# Altium schematic sheet MCU+PWR+USB+SPORT.SchDoc
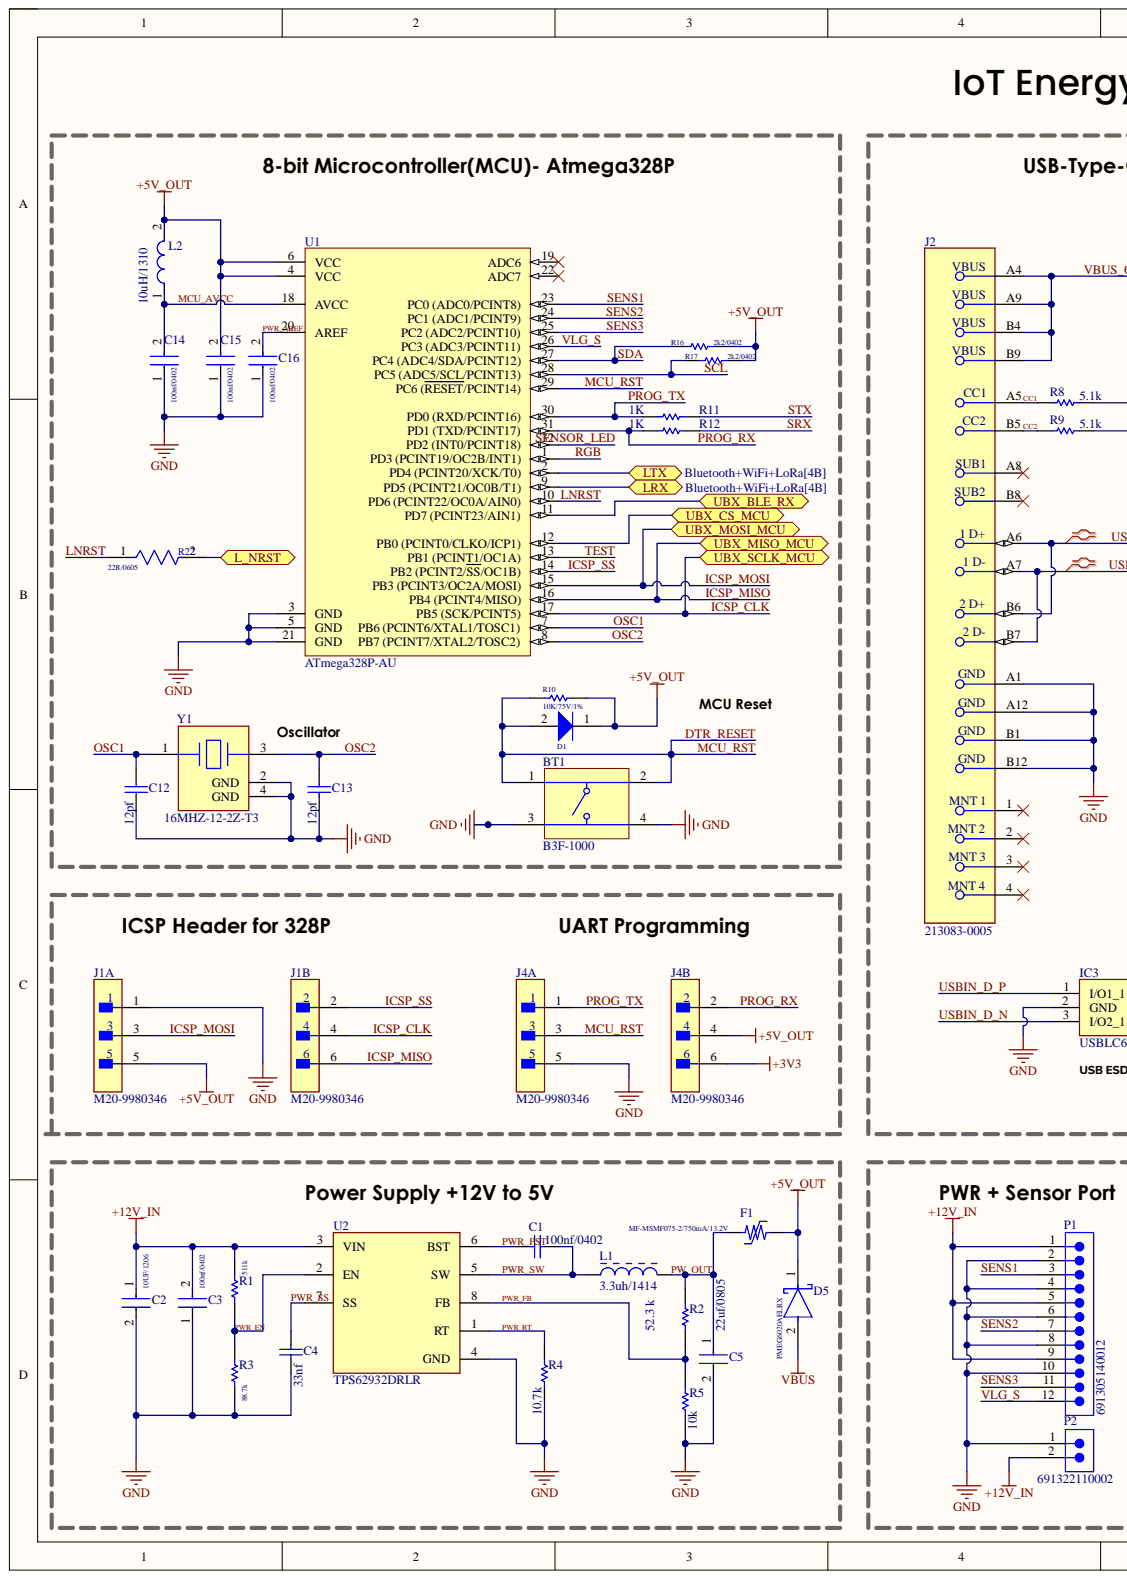
[diagram p0: MCU+PWR+USB+SPORT.SchDoc, left]
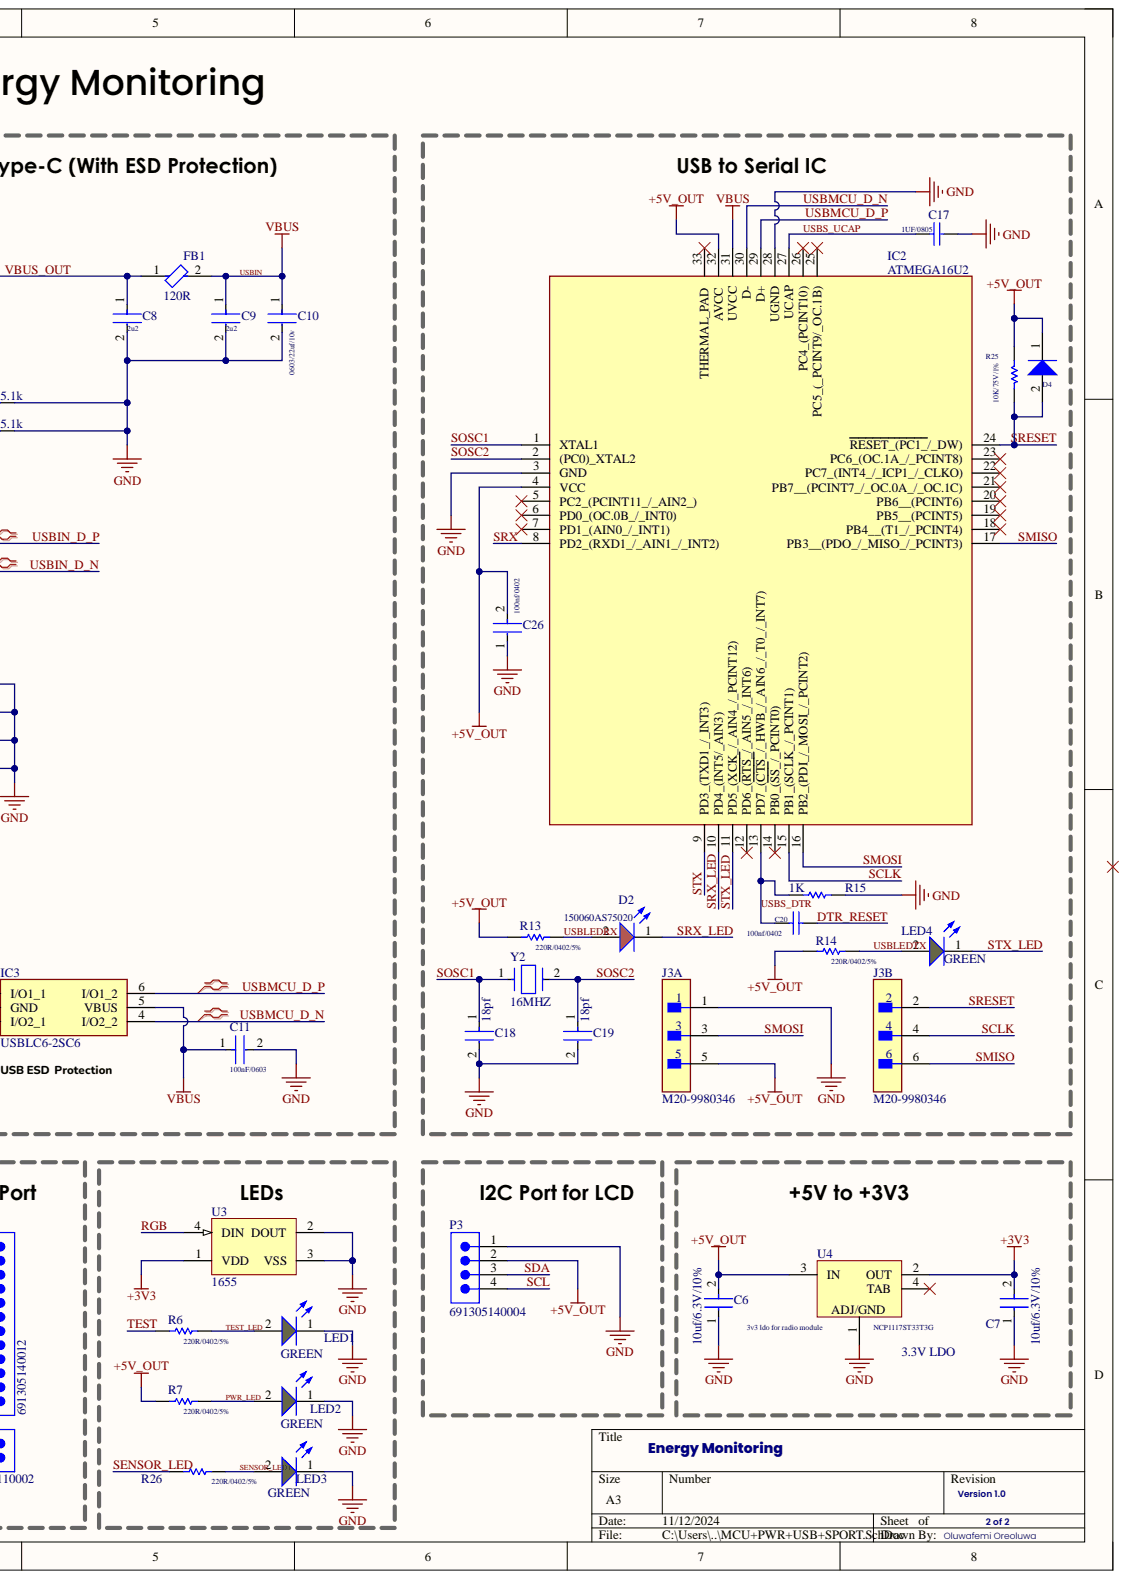
[diagram p1: MCU+PWR+USB+SPORT.SchDoc, right]

## Parts
BT1  B3F-1000  [OMRON-B3F-1000-4_V]
C1  100nf/0402  [CAPC1005X55X25LL05T10]
C2  10UF/1206  [FP-C3216-160-0_2-IPC_A]
C3  100nf/0402  [FP-GRM15-MFG]
C4  33nf  [CAPC1005X55X25NL05T10]
C5  22uf/0805  [FP-GRM21B-0_2-e0_2_0_7-IPC_C]
C6  10uf/6.3V/10%  [FP-C1608-080-0_1-IPC_B]
C7  10uf/6.3V/10%  [FP-C1608-080-0_1-IPC_B]
C8  2u2  [FP-GRM188-0_1-e0_2_0_5-MFG]
C9  2u2  [FP-GRM188-0_1-e0_2_0_5-MFG]
C10  0603/22uf/10v  [FP-GRM188-0_2-IPC_C]
C11  100nF/0603  [FP-CGA3E-0_3-0_1-IPC_A]
C12  12pf  [CAPC2013X94X50LL20T25]
C13  12pf  [CAPC2013X94X50LL20T25]
C14  100nf/0402  [FP-GRM15-MFG]
C15  100nf/0402  [FP-GRM15-MFG]
C16  100nf/0402  [FP-GRM15-MFG]
C17  1UF/0805  [CAPC2013X140X50LL20T25]
C18  18pf  [FP-C0805C-DN-MFG]
C19  18pf  [FP-C0805C-DN-MFG]
C20  100nf/0402  [CAPC1005X55X25LL05T10]
C26  100nf/0402  [FP-GRM15-MFG]
D1  BAT54HT1G/30V/2A  [ONSC-SOD-323-2-477-02_V]  (value not drawn)
D2  150060AS75020  [WL-SMCW_0603_150060xx75020]
D4  BAT54HT1G/30V/2A  [ONSC-SOD-323-2-477-02_V]  (value not drawn)
D5  PMEG6020AELRX  [FP-SOD123W-MFG]
F1  MF-MSMF075-2/750mA/13.2V  [BOUR-MF-MSMF050-2_V]
FB1  120R  [FP-BLM18-0_15-t0_8-IPC_A]
IC2  ATMEGA16U2  [QFN50P500X500X100-33N-D]
IC3  USBLC6-2SC6  [SOT95P280X145-6N]
J1  M20-9980346  [FP-M20-9980346-MFG]  (parts A,B)
J2  213083-0005  [FP-2130830005-MFG]
J3  M20-9980346  [FP-M20-9980346-MFG]  (parts A,B)
J4  M20-9980346  [FP-M20-9980346-MFG]  (parts A,B)
L1  3.3uh/1414  [BOUR-SRN3015-2_V]
L2  10uH/1310  [FP-CB3225-IPC_A]
LED1  GREEN  [WL-SMCW_0603_150060xx75000]
LED2  GREEN  [WL-SMCW_0603_150060xx75000]
LED3  GREEN  [WL-SMCW_0603_150060xx75000]
LED4  GREEN  [WL-SMCW_0603_150060xx75000]
P1  691305140012  [691305140012]
P2  691322110002  [691322110002]
P3  691305140004  [691305140004]
R1  511k  [RESC1005X40X25NL05T05]
R2  52.3 k  [RESC1005X40X25LL05T05]
R3  88.7k  [RESC1005X40X25NL05T05]
R4  10.7k  [RESC1005X40X25NL05T05]
R5  10k  [RESC1609X50X30NL8T20]
R6  220R/0402/5%  [RESC1005X40X25LL05T05]
R7  220R/0402/5%  [RESC1005X40X25LL05T05]
R8  5.1k  [RESC0805(2012)_N]
R9  5.1k  [RESC0805(2012)_N]
R10  10K/75V/1%  [RESC1609X50X30NL8T20]
R11  1K  [RESC3216X70X50LL05T20]
R12  1K  [RESC3216X70X50LL05T20]
R13  220R/0402/5%  [RESC1005X40X25LL05T05]
R14  220R/0402/5%  [RESC1005X40X25LL05T05]
R15  1K  [RESC3216X70X50LL05T20]
R16  2k2/0402  [RESC1005X40X25NL5T10]
R17  2k2/0402  [RESC1005X40X25NL5T10]
R22  22R/0605  [FP-CPF0603-MFG]
R25  10K/75V/1%  [RESC1609X50X30NL8T20]
R26  220R/0402/5%  [RESC1005X40X25LL05T05]
U1  ATmega328P-AU  [32A_M]
U2  TPS62932DRLR  [FP-DRL0008A-MFG]
U3  1655  [ADA-RGB-1655_V]
U4  NCP1117ST33T3G  [ONSC-SOT-223-4-318H-01_A_V]
Y1  16MHZ-12-2Z-T3  [FP-ABM8AIG-MFG]
Y2  16MHZ  [FP-ABM7-MFG]

## Ports
L_NRST, LRX, LTX, UBX_BLE_RX, UBX_CS_MCU, UBX_MISO_MCU, UBX_MOSI_MCU, UBX_SCLK_MCU

## Nets
+3V3: C7.2 J4.6 U3.1(VDD) U4.2(OUT)
+5V_OUT: C6.2 C15.2 C26.2 D1.1 D4.1 D5.1 F1.2 IC2.4(VCC) IC2.32(AVCC) J1.5 J3.5 J4.4 L2.2 P3.2 R7.1 R10.1 R13.1 R14.1 R16.1 R17.1 R25.1 U1.4(VCC) U1.6(VCC) U4.3(IN)
+12V_IN: C2.1 C3.2 P1.1 P1.5 P1.9 P2.2 R1.2 U2.3(VIN)
GND: BT1.3 BT1.4 C2.2 C3.1 C4.1 C5.2 C6.1 C7.1 C8.2 C9.2 C10.2 C11.2 C12.1 C13.1 C14.1 C15.1 C16.1 C17.2 C18.2 C19.2 C26.1 IC2.3(GND) IC2.28(UGND) IC3.2(GND) J1.1 J2.A1 J2.A12 J2.B1 J2.B12 J3.1 J4.5 LED1.1 LED2.1 LED3.1 P1.2 P1.4 P1.6 P1.8 P1.10 P2.1 P3.1 R3.1 R4.1 R5.1 R8.1 R9.1 R15.2 U1.3(GND) U1.5(GND) U1.21(GND) U2.4(GND) U3.2(DOUT) U3.3(VSS) U4.1 Y1.2(GND) Y1.4(GND)
CC1: J2.A5 R8.2
CC2: J2.B5 R9.2
DTR_RESET: BT1.1 BT1.2 C20.2 D1.2 J4.3 R10.2 U1.29(PC6_(RESET/PCINT14))
ICSP_CLK: J1.4 U1.17(PB5_(SCK/PCINT5)) ; port UBX_SCLK_MCU
ICSP_MISO: J1.6 U1.16(PB4_(PCINT4/MISO)) ; port UBX_MISO_MCU
ICSP_MOSI: J1.3 U1.15(PB3_(PCINT3/OC2A/MOSI)) ; port UBX_MOSI_MCU
ICSP_SS: J1.2 U1.14(PB2_(PCINT2/SS/OC1B))
L_NRST: R22.2 ; port L_NRST
LNRST: R22.1 U1.10(PD6_(PCINT22/OC0A/AIN0))
LRX: U1.9(PD5_(PCINT21/OC0B/T1)) ; port LRX
LTX: U1.2(PD4_(PCINT20/XCK/T0)) ; port LTX
MCU_AVCC: C14.2 L2.1 U1.18(AVCC)
OSC1: C12.2 U1.7 Y1.1
OSC2: C13.2 U1.8 Y1.3
PROG_RX: J4.2 R12.1 U1.31(PD1_(TXD/PCINT17))
PROG_TX: J4.1 R11.1 U1.30(PD0_(RXD/PCINT16))
PW_OUT: C5.1 F1.1 L1.2 R2.2
PWR_AREF: C16.2 U1.20(AREF)
PWR_EN: R1.1 R3.2 U2.2(EN)
PWR_FB: R2.1 R5.2 U2.8(FB)
PWR_LED: LED2.2 R7.2
PWR_PST: C1.1 U2.6(BST)
PWR_RT: R4.2 U2.1(RT)
PWR_SS: C4.2 U2.7(SS)
PWR_SW: C1.2 L1.1 U2.5(SW)
RGB: U1.1(PD3_(PCINT19/OC2B/INT1)) U3.4(DIN)
SCL: P3.4 R17.2 U1.28(PC5_(ADC5/SCL/PCINT13))
SCLK: IC2.15(PB1_(SCLK_/_PCINT1)) J3.4
SDA: P3.3 R16.2 U1.27(PC4_(ADC4/SDA/PCINT12))
SENS1: P1.3 U1.23(PC0_(ADC0/PCINT8))
SENS2: P1.7 U1.24(PC1_(ADC1/PCINT9))
SENS3: P1.11 U1.25
SENSOR_LED: R26.1 U1.32(PD2_(INT0/PCINT18))
SENSOR_LED1: LED3.2 R26.2
SMISO: IC2.17(PB3__(PDO_/_MISO_/_PCINT3)) J3.6
SMOSI: IC2.16(PB2_(PDI_/_MOSI_/_PCINT2)) J3.3
SOSC1: C18.1 IC2.1(XTAL1) Y2.1
SOSC2: C19.1 IC2.2((PC0)_XTAL2) Y2.2
SRESET: D4.2 IC2.24(RESET_(PC1_/_DW)) J3.2 R25.2
SRX: IC2.8(PD2_(RXD1_/_AIN1_/_INT2)) R12.2
SRX_LED: D2.1 IC2.10(PD4_(INT5/_AIN3))
STX: IC2.9(PD3_(TXD1_/_INT3)) R11.2
STX_LED: IC2.11(PD5_(XCK_/_AIN4_/_PCINT12)) LED4.1
TEST: R6.1 U1.13(PB1_(PCINT1/OC1A))
TEST_LED: LED1.2 R6.2
UBX_BLE_RX: U1.11(PD7_(PCINT23/AIN1)) ; port UBX_BLE_RX
UBX_CS_MCU: U1.12(PB0_(PCINT0/CLKO/ICP1)) ; port UBX_CS_MCU
USBIN: C9.1 C10.1 C11.1 D5.2 FB1.2 IC2.31(UVCC) IC3.5(VBUS)
USBIN_D_N: IC3.3(I/O2_1) J2.A7 J2.B7
USBIN_D_P: IC3.1(I/O1_1) J2.A6 J2.B6
USBLEDRX: D2.2 R13.2
USBLEDTX: LED4.2 R14.2
USBMCU_D_N: IC2.30(D-) IC3.4(I/O2_2)
USBMCU_D_P: IC2.29(D+) IC3.6(I/O1_2)
USBS_DTR: C20.1 IC2.13(PD7_(CTS_/_HWB_/_AIN6_/_T0_/_INT7)) R15.1
USBS_UCAP: C17.1 IC2.27(UCAP)
VBUS_OUT: C8.1 FB1.1 J2.A4 J2.A9 J2.B4 J2.B9
VLG_S: P1.12 U1.26(PC3_(ADC3/PCINT11))

Not connected: IC2 5(PC2_(PCINT11_/_AIN2_)) 6(PD0_(OC.0B_/_INT0)) 7(PD1_(AIN0_/_INT1)) 12(PD6_(RTS_/_AIN5_/_INT6)) 14(PB0_(SS_/_PCINT0)) 18(PB4__(T1_/_PCINT4)) 19(PB5__(PCINT5)) 20(PB6__(PCINT6)) 21(PB7__(PCINT7_/_OC.0A_/_OC.1C)) 22(PC7_(INT4_/_ICP1_/_CLKO)) 23(PC6_(OC.1A_/_PCINT8)) 25(PC5_(_PCINT9/_OC.1B)) 26(PC4_(PCINT10)) 33(THERMAL_PAD); J2 1 2 3 4 A8 B8; U1 19(ADC6) 22(ADC7); U4 4(TAB)

## Library data of the parts
BT1 = CMP-1677-00002-1: Tactile Switch, SPST-NO, 0.05 A, 5 to 24 V, -25 to 70 degC, 4-Pin THD, RoHS, Bulk; Capacitance: -45 f; Current Rating: 1.5 A; Gender: Female; Shielding: Shielded; Voltage Rating: 150 V; Component Kind: Standard; ComponentLink1Description: Manufacturer URL; ComponentLink1URL: http://www.omron.com/; ComponentLink2Description: Datasheet; ComponentLink2URL: http://www.omron.com/ecb/products/pdf/en-b3f.pdf; Contact Material: Brass; Contact Plating: Gold; DatasheetVersion: Jan-15; Height: 14.99 mm; Lead Pitch: 1.27 mm; Length: 18.34 mm; Library Name: Altium Content Vault; Max Operating Temperature: 70 degC; Min Operating Temperature: -40 degC; Mount: Through Hole; Package Quantity: 1080; PackageDescription: 4-Pin Through Hole Device, Body 6 x 6 mm; PackageReference: B3F-1000; Packaging: Tray; Pins: 8; Radiation Hardening: No; RoHSCompliant: true; Width: 25.4 mm
C1 C20 = CMP-2000-06331-1: Chip Capacitor, 0.1 uF, +/- 10%, 10 V, -55 to 125 degC, 0402 (1005 Metric), RoHS, Tape and Reel; Manufacturer: Murata; Capacitance: 100000pF; Dielectric: X7R; Rated Voltage: 10V; Case Size/Dimension: 0402; Component Kind: Standard; Datasheet URL: http://search.murata.co.jp/Ceramy/image/img/A01X/G101/ENG/GRM155R71A104KA01-01.pdf; Datasheet Version: 02/2016; Library Name: Altium Content Vault; Manufacturer URL: http://www.murata.com/; Mounting Technology: SurfaceMount; Package Description: 2-Pin Surface Mount Device, Body 1 x 0.5 mm; Package Reference: 0402; Tolerance (%): ±10%
C2 = CMP-2000-06045-2: Multilayer Ceramic Capacitors 10uF ±10% 50V X5R SMD 1206; Capacitance: 10uF; Case Code (Imperial): 1206; Case Code (Metric): 3216; Case/Package: 1206; Component Kind: Standard; Construction: Flat; Depth: 1.6mm; Dielectric: X5R; Dielectric Material: Ceramic; Height: 1.6mm; Lead Free: Lead Free; Length: 3.2mm; Library Name: Altium Content Vault; Max Operating Temperature: 85°C; Min Operating Temperature: -55°C; Mount: Surface Mount; Package Quantity: 1; Packaging: Tape and Reel; Radiation Hardening: No; REACH SVHC: No SVHC; Resistor Type: Ceramic; RoHS Compliant: Yes; Series: C; Termination: SMD/SMT; Thickness: 0.071inch; Tolerance: 10%; Voltage: 50V; Voltage Rating: 50V; Voltage Rating (DC): 50V; Weight: 0.000571oz; Width: 0.063inch
C3 C14-C16 C26 = CMP-2100-03641-2: CAP CER 0.1UF 16V X7R 0402; Manufacturer: Murata; Manufacturer Part Number: GRM155R71C104KA88D; Capacitance: 0.10μF; Material: Ceramic; Standoff Height: 0mm; Tolerance: 10%; Value: 0.10μF; Voltage Rating: 16Vdc; Category: Cap; Component Kind: Standard; ComponentLink2Description: Datasheet; ComponentLink2URL: https://search.murata.co.jp/Ceramy/image/img/A01X/G101/ENG/GRM155R71H471KA01-01A.pdf; Device Class L1: Passive Components; Device Class L2: Capacitors; Device Class L3: Ceramic Capacitors; Digikey Description: CAP CER 0.1UF 16V X7R 0402; Height: 0.55mm; Ipc Land Pattern Name: CAPC10050X219; Lead Free: yes; Library Name: Altium Content Vault; Mouser Description: 0402 0.1 uF 16V ±10 % Tolerance X7R SMT Multilayer Ceramic Capacitor; Octopart: https://octopart.com/grm155r71c104ka88d-murata-196880; Package: GRM15; RoHS: TRUE; Temperature: -55°C to +125°C; Temperature Characteristic: X7R; Temperature Range High: +125°C; Temperature Range Low: -55°C
C4 = CMP-2008-04594-1: Manufacturer: Murata; Part Number: GRM155R71A333KA01D; Component Kind: Standard; Library Name: Altium Content Vault
C5 = CMP-06035-039918-1: Chip Multilayer Ceramic Capacitors for General Purpose, 0805, 22uF, X5R, 15%, 20%, 10V; Dissipation Factor: 0.035%; Capacitance: 22uF; Case Code (Imperial): 0805; Case Code (Metric): 2012; Case/Package: 0805; Component Kind: Standard; Depth: 1.25mm; Dielectric: X5R; Dielectric Material: Ceramic; Height: 1.25mm; Lead Free: Lead Free; Length: 2mm; Library Name: Altium Content Vault; Max Operating Temperature: 85°C; Min Operating Temperature: -55°C; Mount: Surface Mount; Package Quantity: 3000; Packaging: Tape and Reel; Resistor Type: Ceramic; Termination: SMD/SMT; Thickness: 0.057inch; Tolerance: 20%; Voltage: 10V; Voltage Rating: 10V; Voltage Rating (DC): 10V; Weight: 0.000335oz; Width: 1.25mm
C6 C7 = CMP-08246-005333-1: Multilayer Ceramic Capacitors 10μF ±10% 6.3V X5R SMD 0603; Capacitance: 10uF; Case Code (Imperial): 0603; Case Code (Metric): 1608; Case/Package: 0603; Component Kind: Standard; Construction: Flat; Depth: 0.8mm; Dielectric: X5R; Dielectric Material: Ceramic; Height: 0.8mm; Lead Free: Lead Free; Length: 1.6mm; Library Name: Altium Content Vault; Max Operating Temperature: 85°C; Min Operating Temperature: -55°C; Mount: Surface Mount; Package Quantity: 1; Packaging: Tape and Reel; Radiation Hardening: No; REACH SVHC: No SVHC; Resistor Type: Ceramic; RoHS Compliant: Yes; Series: C; Termination: SMD/SMT; Thickness: 0.035inch; Tolerance: 10%; Voltage: 6.3V; Voltage Rating: 6.3V; Voltage Rating (DC): 6.3V; Weight: 7.1E-05oz; Width: 0.031inch
C8 C9 = CMP-2006-02836-2: Chip Multilayer Ceramic Capacitors for General Purpose, 0603, 2.2uF, X7R, 15%, 10%, 10V; Capacitance: 2.2uF; Case Code (Imperial): 0603; Case Code (Metric): 1608; Case/Package: 0603; Component Kind: Standard; Depth: 0.8mm; Dielectric: X7R; Height: 0.8mm; Lead Free: Lead Free; Length: 1.6mm; Library Name: Altium Content Vault; Material: Ceramic; Max Operating Temperature: 125°C; Min Operating Temperature: -55°C; Mount: Surface Mount; Number of Pins: 2; Package Quantity: 1; Packaging: Cut Tape; REACH SVHC: No SVHC; Termination: SMD/SMT; Thickness: 0.035inch; Tolerance: 10%; Voltage: 10V; Voltage Rating: 10V; Voltage Rating (DC): 10V; Weight: 7.1E-05oz; Width: 0.031inch
C10 = CMP-2000-06337-3: Chip Multilayer Ceramic Capacitors for General Purpose, 0603, 22uF, X5R, 15%, 20%, 10V; Capacitance: 22uF; Case Code (Imperial): 0603; Case Code (Metric): 1608; Case/Package: 0603; Component Kind: Standard; Depth: 0.8mm; Dielectric: X5R; Height: 0.8mm; Lead Free: Lead Free; Length: 1.6mm; Library Name: Altium Content Vault; Material: Ceramic; Max Operating Temperature: 85°C; Min Operating Temperature: -55°C; Mount: Surface Mount; Package Quantity: 4000; Packaging: Tape and Reel; REACH SVHC: No SVHC; Termination: SMD/SMT; Thickness: 0.039inch; Tolerance: 20%; Voltage Rating: 10V; Voltage Rating (DC): 10V; Weight: 0.000222oz; Width: 0.8mm
C11 = CMP-2000-07546-2: Cap Ceramic 100nF 50V X7R 10% Pad SMD 0603 +125°C Automotive T/R; Capacitance: 100nF; Case Code (Imperial): 0603; Case Code (Metric): 1608; Case/Package: 0603; Component Kind: Standard; Composition: Ceramic; Depth: 0.8mm; Dielectric: X7R; Height: 0.8mm; Lead Free: Lead Free; Length: 1.6mm; Library Name: Altium Content Vault; Max Operating Temperature: 125°C; Min Operating Temperature: -55°C; Mount: Surface Mount; Package Quantity: 1; Packaging: Tape and Reel; Radiation Hardening: No; Ratings: AEC-Q200; REACH SVHC: No SVHC; Termination: SMD/SMT; Thickness: 0.035inch; Tolerance: 10%; Voltage: 50V; Voltage Rating: 50V; Voltage Rating (DC): 50V; Weight: 7.1E-05oz; Width: 0.8mm
C12 C13 = CMP-2007-04128-1: Manufacturer: AVX Interconnect / Elco; Part Number: 08051A120JAT2A; Component Kind: Standard; Library Name: Altium Content Vault
C17 = CMP-2007-03234-1: Manufacturer: Kemet; Part Number: C0805C105K3RACTU; Component Kind: Standard; Library Name: Altium Content Vault
C18 C19 = CMP-2007-00302-2: CAP CER 18PF 50V C0G/NP0 0805; Manufacturer: KEMET; Manufacturer Part Number: C0805C180J5GACTU; Material: Ceramic; Temperature Characteristic: C0G; Temperature Coefficient: 30ppm/°C; Tolerance: 0.05; Automotive: No; Capacitance: 18 pF; Category: Cap; Component Kind: Standard; ComponentLink2Description: Datasheet; ComponentLink2URL: https://content.kemet.com/datasheets/KEM_C1003_C0G_SMD.pdf; Device Class L1: Passive Components; Device Class L2: Capacitors; Device Class L3: Ceramic Capacitors; Digikey Description: CAP CER 18PF 50V C0G/NP0 0805; Height: 0.88mm; Ipc Land Pattern Name: CAPC200125X78; Lead Free: Yes; Library Name: Altium Content Vault; Mouser Description: Cap Ceramic 18pF 50V C0G 5% SMD 0805 125C Paper T/R; Octopart: https://octopart.com/c0805c180j5gactu-kemet-144810; Package: C0805C-DN; RoHS: TRUE; Temperature: -55°C to +125°C; Temperature Range High: +125°C; Temperature Range Low: -55°C; Value: 18 pF; Voltage Rating: 50 V
D1 D4 = CMP-1055-00462-1: Schottky Barrier Diode, 2-Pin SOD-323, Pb-Free, Tape and Reel; Manufacturer: On Semiconductor; Configuration: Single; IFSM Max (A): 0.6; trr Max (ns): 5; Component Kind: Standard; ComponentLink1Description: Manufacturer URL; ComponentLink1URL: http://www.onsemi.com/; ComponentLink2Description: Datasheet; ComponentLink2URL: http://www.onsemi.cn/pub_link/Collateral/BAT54HT1-D.PDF; ComponentLink3Description: Package Specification; ComponentLink3URL: http://www.onsemi.com/pub_link/Collateral/477-02.PDF.1; DatasheetVersion: Rev. 6; Library Name: Altium Content Vault; Mounting Technology: Surface Mount; PackageDescription: 2-Pin SOD, Body 1.7 x 1.25 mm; PackageReference: SOD-323-2-477-02; PackageVersion: Rev. H, 03/2007; Packing: Tape and Reel; PartNumber: BAT54HT1G; RoHS: TRUE
D2 = CMP-03913-104891423-2: WL-SMCW SMT Mono-Color Chip LED Waterclear super f; Dominant Wavelength: 605nm; Forward Current: 60mA; Forward Voltage: 2V; Luminous Intensity: 140mcd; Max Reverse Voltage (DC): 5V; Peak Wavelength: 610nm; Viewing Angle: 140°; Component Kind: Standard; Height: 250µm; Length: 1.6mm; Library Name: Altium Content Vault; Max Operating Temperature: 85°C; Min Operating Temperature: -40°C; RoHS: Compliant; Width: 800µm
D5 = CMP-14398-000040-1: DIODE SCHOTTKY 60V 2A CFP3; Component Kind: Standard; Library Name: Altium Content Vault; RoHS Compliant: Yes
F1 = CMP-2100-03654-1: PTC Resettable Fuse, 13.2 V, 750 mA, -40 to 85 degC, 2-Pin SMD, RoHS, Tape and Reel; Manufacturer: Bourns; Current Rating: 100A; Power Dissipation: 800mW; Resistance: 450mOhm; Supply Voltage: 13.2V; Case Code (Imperial): 1812; Case Code (Metric): 4532; Case/Package: 1812; Component Kind: Standard; Datasheet URL: http://www.bourns.com/docs/Product-Datasheets/mfmsmf.pdf; Datasheet Version: Rev. AG, 08/2016; Height: 0.85mm; Lead Free: LeadFree; Length: 4.73mm; Library Name: Altium Content Vault; Manufacturer URL: http://www.bourns.com/; Max Current Rating: 100A; Max Operating Temperature: 85degC; Min Operating Temperature: -40degC; Mount: SurfaceMount; Number of Terminals: 2; Package Description: 2-Pin Surface Mount Device, Body 4.55 x 3.24 mm; Package Quantity: 2000; Package Reference: MF-MSMF050-2; Packaging: TapeandReel; Radiation Hardening: No; REACH SVHC: NoSVHC; RoHSCompliant: true; Termination: SMD/SMT; Voltage Rating: 13.2V; Voltage Rating (DC): 13.2V; Width: 3.41mm
FB1 = CMP-0686-00557-7: Chip Ferrite Bead, 0603, 120Ω @ 100MHz, 0.05Ω, 25%, 2A; DC Resistance (DCR): 50mR; Material: Steel; Number of Circuits: 1; Shielding: Unshielded; Case Code (Imperial): 0603; Case Code (Metric): 1608; Case/Package: 0603; Component Kind: Standard; Core Material: Ferrite; Current Rating: 2A; DC Current: 2A; Depth: 0.8mm; Frequency: 100MHz; Height: 0.8mm; Impedance: 120R; Lead Free: Lead Free; Length: 1.6mm; Library Name: Altium Content Vault; Max Current Rating: 2A; Max DC Current: 2A; Max Operating Temperature: 125°C; Min Operating Temperature: -55°C; Number of Lines: 1; Number of Pins: 2; Package Quantity: 1; Packaging: Tape and Reel; Radiation Hardening: No; REACH SVHC: No SVHC; RoHS Compliant: Yes; Termination: SMD/SMT; Test Frequency: 100MHz; Tolerance: 25%; Width: 0.8mm
IC2 = ATMEGA16U2: Integrated Circuit; Manufacturer_Name: Microchip; Component Kind: Standard; Datasheet Link: http://ww1.microchip.com/downloads/en/DeviceDoc/doc7799.pdf; Height: 1mm; Manufacturer_Part_Number: ATMEGA16U2; Mouser Part Number: N/A; Mouser Price/Stock: https://www.mouser.co.uk/ProductDetail/Microchip-Technology-Atmel/ATMEGA16U2?qs=HbI%2FMOA3e14pFnJig5hY1g%3D%3D
IC3 = USBLC6-2SC6: Integrated Circuit; Manufacturer_Name: STMicroelectronics; Arrow Part Number: USBLC6-2SC6; Arrow Price/Stock: https://www.arrow.com/en/products/usblc6-2sc6/stmicroelectronics?region=nac; Component Kind: Standard; Datasheet Link: http://www.st.com/st-web-ui/static/active/en/resource/technical/document/datasheet/CD00050750.pdf; Height: 1.45mm; Manufacturer_Part_Number: USBLC6-2SC6; Mouser Part Number: 511-USBLC6-2SC6; Mouser Price/Stock: https://www.mouser.co.uk/ProductDetail/STMicroelectronics/USBLC6-2SC6?qs=po45yt2pPpu%2FhNIlwQdTlg%3D%3D; Supplier 1: Mouser; Supplier Part Number 1: 511-USBLC6-2SC6
J1 J3 J4 = CMP-2000-06636-2: CONN HEADER VERT 6POS 2.54MM; Manufacturer: Harwin; Conn Gender: Male; Conn Orientation: Vertical; Current Rating: 3A; Number Of Contacts: 6; Automotive: No; Category: Conn; Component Kind: Standard; ComponentLink2Description: Datasheet; ComponentLink2URL: https://cdn.harwin.com/pdfs/M20-998.pdf; Contact Material: Tin,Nickel; Device Class L1: Connectors; Device Class L2: Headers and Wire Housings; Device Class L3: unset; Digikey Description: CONN HEADER VERT 6POS 2.54MM; Height: 8.99mm; Lead Free: Yes; Library Name: Altium Content Vault; Manufacturer Part Number: M20-9980346; Mouser Description: 2.54mm (0.1") Pitch DIL Vertical PC Tail Pin Header, 6.1mm mating pin height, tin, 3+3 contacts; Number Of Rows: 2; Octopart: https://octopart.com/m20-9980346-harwin-5436545; Package: M20-9980346; Pitch: 2.54mm; RoHS: TRUE; Temperature: -40°C to +105°C; Temperature Range High: +105°C; Temperature Range Low: -40°C
J2 = CMP-04767-000030-2: CONN RCP USB2.0 TYP C 24P SMD RA; NexarPartId: 114105384; Current Rating: 5A; Gender: Receptacle; Number of Contacts: 16; Voltage Rating: 30V; Component Kind: Standard; Contact Material: Gold, Nickel; Country of Origin: CN; Library Name: Altium Content Vault; Max Operating Temperature: 85°C; Min Operating Temperature: -40°C; Schedule B: 8536695050, 8536695050; USB Standard: USB 2.0
L1 = CMP-0007-00001-1: Semi-shielded Power Inductor, 3.3 uH, +/- 20%, 1.6 A, -40 to 125 degC, 3 x 3 x 1.5 mm SMD, RoHS and Halogen Free, Tape and Reel; Manufacturer: Bourns; PartNumber: SRN3015-3R3M; DCR Max: 0.112 Ohm; Inductance: 3.3 uH; Inductance tolerance: +/- 20%; Isat: 1.4 A; Rated current: 1.6 A; Resistance to Solder Heat: +260 degC for 10 sec.; SRF Min: 75 MHz; Component Kind: Standard; ComponentLink1Description: Manufacturer URL; ComponentLink1URL: http://www.bourns.com/; ComponentLink2Description: Datasheet; ComponentLink2URL: http://www.bourns.com/data/global/pdfs/SRN3015.pdf; ComponentLink3Description: Package Specification; ComponentLink3URL: http://www.bourns.com/data/global/pdfs/SRN3015.pdf; DatasheetVersion: Aug-11; Library Name: Altium Content Vault; Mounting Technology: Surface Mount; Operating Temperature: -40 to 125 degC; PackageDescription: 2-Pin SMD, Body 3 x 3 mm, Height 1.5 mm; PackageReference: SRN3015; Packing: Tape and Reel; RoHS: TRUE
L2 = CMP-14484-000123-1: SMD Inductors 10uH ±20% 0.9A 0.1729Ω 1210; Core Material: Ferrite; Current Rating: 900mA; DC Resistance (DCR): 172.9mR; Self Resonant Frequency: 23MHz; Shielding: Unshielded; Test Frequency: 100kHz; Case Code (Imperial): 1210; Case Code (Metric): 3225; Case/Package: 1210; Component Kind: Standard; DC Current: 900mA; Depth: 2.7mm; Halogen Free: Halogen Free; Height: 2.5mm; Height - Seated (Max): 0.106inch; Inductance: 10uH; Inductance Tolerance: 20%; Lead Free: Lead Free; Length: 3.4mm; Library Name: Altium Content Vault; Max DC Current: 900mA; Max Operating Temperature: 105°C; Military Standard: Not; Min Operating Temperature: -40°C; Mount: Surface Mount; Number of Pins: 2; Package Quantity: 1000; Packaging: Tape and Reel; Radiation Hardening: No; Resistor Type: Wirewound; Saturation Current: 900mA; Series: CB; Termination: SMD/SMT; Tolerance: 20%; Width: 0.098inch
LED1-LED4 = CMP-1426-00006-6: NexarPartId: 29717778; Dominant Wavelength: 570nm; Forward Current: 100mA; Forward Voltage: 2V; Lens Style: Clear, Rectangular; Luminous Intensity: 40mcd; Max Junction Temperature (Tj): 95°C; Max Reverse Voltage (DC): 5V; Peak Wavelength: 572nm; Power Dissipation: 72mW; Test Current: 20mA; Viewing Angle: 140°; Case Code (Imperial): 0603; Case Code (Metric): 1608; Case/Package: 0603; Color: Green; Component Kind: Standard; Height: 700µm; Length: 1.6mm; Library Name: Altium Content Vault; Max Operating Temperature: 85°C; Min Operating Temperature: -40°C; Mount: Surface Mount; Number of Pins: 2; Packaging: Tape and Reel; REACH SVHC: Unknown; Reverse Voltage: 5V; RoHS: Compliant; Wavelength: 570nm; Width: 800µm
P1 = CMP-1502-01782-2: NexarPartId: 105952413; Component Kind: Standard; Library Name: Altium Content Vault
P2 = CMP-1502-01791-3: NexarPartId: 18818346; Current: 10A; Gender: Male; Number of Contacts: 2; Number of Levels: 1; Pitch: 3.5052mm; Voltage: 300V; Color: Green; Component Kind: Standard; Contact Material: Brass; Current Rating: 10A; Height: 7.4mm; Lead Free: Lead Free; Lead Pitch: 3.5mm; Length: 10.5mm; Library Name: Altium Content Vault; Max Operating Temperature: 105°C; Min Operating Temperature: -40°C; Mount: Through Hole; Number of Pins: 2; Number of Positions Per Level: 2; Number of Rows: 1; Packaging: Box; REACH SVHC: Unknown; RoHS: Compliant; Termination: Solder; Voltage Rating: 300V; Width: 8.1mm
P3 = CMP-1502-01777-2: NexarPartId: 78871379; Gender: Male; Component Kind: Standard; Lead Pitch: 3.5mm; Library Name: Altium Content Vault; Number of Pins: 4; Packaging: Box; RoHS: Non-Compliant
R1 = CMP-2002-00076-1: Manufacturer: Vishay Dale; Part Number: CRCW0402511KFKED; Component Kind: Standard; Library Name: Altium Content Vault
R2 = CMP-2002-00086-1: Manufacturer: Vishay Dale; Part Number: CRCW040252K3FKED; Component Kind: Standard; Library Name: Altium Content Vault
R3 = CMP-2002-00251-1: Manufacturer: Vishay Dale; Part Number: CRCW040288K7FKED; Component Kind: Standard; Library Name: Altium Content Vault
R4 = CMP-1011-00626-2: Manufacturer: Vishay Dale; Part Number: CRCW040210K7FKED; Component Kind: Standard; Library Name: Altium Content Vault
R5 R10 R25 = CMP-1666-00005-4: Chip Resistor, 10 KOhm, +/- 1%, 100 mW, -55 to 155 degC, 0603 (1608 Metric), RoHS, Tape and Reel; Manufacturer: Vishay Semiconductor; Voltage Rating: 75 V; Component Kind: Standard; ComponentLink1Description: Manufacturer URL; ComponentLink1URL: http://www.vishay.com/; ComponentLink2Description: Datasheet; ComponentLink2URL: https://datasheet.ciiva.com/11809/0900766b80d98afc-11809037.pdf; DatasheetVersion: 06/2012; Height: 0.45 mm; Length: 1.55 mm; Library Name: Altium Content Vault; Max Operating Temperature: 155 degC; Min Operating Temperature: -55 degC; Mounting Technology: Surface Mount; Package Quantity: 5000; PackageDescription: 2-Pin Surface Mount Device, Body 1.575 x 0.85 mm; PackageReference: 0603; Packaging: Tape and Reel; REACH SVHC: Unknown; RoHSCompliant: true
R6 R7 R13 R14 R26 = CMP-2002-00487-3: Chip Resistor, 220 Ohm, +/- 5%, 100 mW, -55 to 155 degC, 0402 (1005 Metric), RoHS, Tape and Reel; Manufacturer: Basic Passives; PartNumber: ERJ-2GEJ221X; Case\/Package: 0402; Power Rating: 100 mW; Resistance: 220 Ohm; Voltage Rating: 50 V; Case Code (Imperial): 0402; Case Code (Metric): 1005; Component Kind: Standard; ComponentLink1Description: Manufacturer URL; ComponentLink1URL: http://www.panasonic.com/; ComponentLink2Description: Datasheet; ComponentLink2URL: http://industrial.panasonic.com/cdbs/www-data/pdf/RDA0000/AOA0000C84.pdf; DatasheetVersion: 04/2015; Height: 0.35 mm; Length: 1 mm; Library Name: Altium Content Vault; Max Operating Temperature: 155 degC; Min Operating Temperature: -55 degC; Mount: Surface Mount; Mounting Technology: Surface Mount; Package Quantity: 10000; PackageDescription: 2-Pin Surface Mount Device, Body 1 x 0.5 mm; PackageReference: 0402; Packaging: Tape and Reel; Pins: 2; Radiation Hardening: No; REACH SVHC: Unknown; RoHSCompliant: true; Tolerance: 5%; Width: 0.5 mm
R8 R9 = CMP-1013-00591-1: 5K11 0.125W 1% 0805 (2012 Metric)  SMD; Case-EIA: 0805; Case-Metric: 2012; Value: 5110.00 Ohm; Component Kind: Standard; Library Name: Altium Content Vault; Power: 0.125 W; Technology: SMT; Tolerance: 1 %
R11 R12 R15 = CMP-2003-00017-1: Manufacturer: Panasonic; Part Number: ERJ-8ENF1001V; Component Kind: Standard; Library Name: Altium Content Vault
R16 R17 = CMP-2002-07969-2: Chip Resistor, 2.2 KOhm, +/- 5%, 0.063 W, -55 to 155 degC, 0402 (1005 Metric), RoHS, Tape and Reel; Case\Package: 0402; Power Rating: 63 mW; Voltage Rating: 50 V; Case Code (Imperial): 0402; Case Code (Metric): 1005; Component Kind: Standard; ComponentLink1Description: Manufacturer URL; ComponentLink1URL: http://www.yageo.com/; ComponentLink2Description: Datasheet; ComponentLink2URL: http://www.yageo.com/documents/recent/PYu-RC_Group_51_RoHS_L_04.pdf; DatasheetVersion: Rev. 4, 02/2016; Height: 0.35 mm; Length: 1 mm; Library Name: Altium Content Vault; Max Operating Temperature: 155 degC; Min Operating Temperature: -55 degC; Mount: Surface Mount; Mounting Technology: Surface Mount; Package Quantity: 10000; PackageDescription: 2-Pin Surface Mount Device, Body 1 x 0.5 mm; PackageReference: 0402; Packaging: Tape and Reel; Pins: 2; REACH SVHC: No SVHC; Resistance: 2.2 kohm; RoHSCompliant: true; Tolerance: 5%; Voltage Rating (DC): 50 V; Width: 0.5 mm
R22 = CMP-03211-007811-1: RES SMD 22Ω 0.1% 1/16W 0603; Manufacturer: TE Connectivity; Manufacturer Part Number: CPF0603B22RE1; Power Rating: 0.063W; Temperature Coefficient: 25ppm/°C; Voltage Rating: 50V; Automotive: No; Category: Res; Component Kind: Standard; ComponentLink2Description: Datasheet; ComponentLink2URL: https://www.te.com/commerce/DocumentDelivery/DDEController?Action=showdoc&DocId=Data+Sheet%7F1773200%7FM%7Fpdf%7FEnglish%7FENG_DS_1773200_M.pdf%7F3-1676481-2; Composition: Thin Film; Device Class L1: Passive Components; Device Class L2: Resistors; Device Class L3: Chip SMD Resistors; Height: 0.55mm; Ipc Land Pattern Name: RESC15580X45; Lead Free: Yes; Library Name: Altium Content Vault; Octopart: https://octopart.com/cpf0603b22re1-te+connectivity-9539398; octopart_url: https://octopart.com/cpf0603b22re1-te+connectivity-9539398; Package: CPF0603; packaging: 1k Reel; Resistance: 22Ω; RoHS: TRUE; Series: CPF; Temperature: -55°C to +155°C; Temperature Range High: +155°C; Temperature Range Low: -55°C; Tolerance: 0.1%; Value: 22Ω
U1 = CMP-0095-00269-2: 8-bit AVR Microcontroller, 32KB Flash, 1KB EEPROM, 2KB SRAM, 32-pin TQFP, Industrial Grade (-40°C to 85°C); Code_JEDEC: MS-026-ABA; Component Kind: Standard; ComponentLink1Description: Manufacturer URL; ComponentLink1URL: http://www.atmel.com/; ComponentLink2Description: ATmega48A/48PA/88A/88PA/168A/168PA/328/328P Datasheet; ComponentLink2URL: http://www.atmel.com/dyn/resources/prod_documents/doc8271.pdf; ComponentLink3Description: ATmega48A/48PA/88A/88PA/168A/168PA/328/328P Summary; ComponentLink3URL: http://www.atmel.com/dyn/resources/prod_documents/8271S.pdf; DatasheetVersion: revD, May-2011; Library Name: Altium Content Vault; PackageDescription: 32-Lead, 7x7x1.0 mm Body, 0.8mm Lead Pitch, Thin Profile Plastic Quad Flat Package (TQFP); PackageReference: 32A; PackageVersion: revC, Oct-2010; RevisionNote: DatasheetVersion & PackageVersion updated. URLs added.
U2 = CMP-04918-000714-1: 3.8-V TO 30-V INPUT, 2-A, 200-KH; Component Kind: Standard; Library Name: Altium Content Vault
U3 = CMP-2000-05026-1: Intelligent Control LED Integrated Light Source, 3.5 to 5.3 V, -25 to 80 degC, 4-Pin SMD, RoHS, Tape and Reel; Manufacturer: Adafruit-Industries-LLC; Configuration: Discrete; Number of LEDs: 10; Utilized LED: WS2812(5050); Category: Optoelectronics; Color: Red,Green,Blue(RGB); Component Kind: Standard; Controller Type: -; Datasheet URL: http://datasheet.octopart.com/ADA1655-Adafruit-Industries-datasheet-32028139.pdf; Datasheet Version: May-13; Family: Addressable,Specialty; Interface: -; Library Name: Altium Content Vault; Manufacturer URL: https://www.adafruit.com/; Mounting Type: SurfaceMount; Package Description: 4-Pin Surface Mount Device, Body 5 x 5 mm; Package Reference: 1655; Series: Neopixel; Size / Dimension: 5.00mmLx5.00mmW
U4 = CMP-1679-00010-1: Low-Dropout Positive Fixed Voltage Regulator, 1 A, 3.3 V Output Voltage, 0 to 125 degC, 4-Pin SOT-223 (318H-01), Pb-Free, Tape and Reel; Case\Package: 0603; Power Rating: 100 mW; Resistance: 220 kohm; Tolerance: 1%; Type (Resistor): Thick Film; Voltage Rating: 75 V; Case Code (Imperial): 0603; Case Code (Metric): 1608; Component Kind: Standard; ComponentLink1Description: Manufacturer URL; ComponentLink1URL: http://www.onsemi.com/; ComponentLink2Description: Datasheet; ComponentLink2URL: http://www.onsemi.com/pub_link/Collateral/NCP1117-D.PDF; DatasheetVersion: Rev. 27, 09/2014; Height: 0.45 mm; Length: 1.55 mm; Library Name: Altium Content Vault; Max Operating Temperature: 155 degC; Min Operating Temperature: -55 degC; Mount: Surface Mount; Package Quantity: 5000; PackageDescription: 4-Pin Small Outline Transistor, Body 6.5 x 3.5 mm, Pitch 2.3 mm; PackageReference: SOT-223-4-318H-01_A; Packaging: Tape and Reel; Radiation Hardening: No; REACH SVHC: Unknown; RoHSCompliant: true; Weight: 7.1E-05 oz; Width: 0.85 mm
Y1 = CMP-27762-000014-2: Crystal 16MHz ±20ppm (Tol) ±50ppm (Stability) 12pF FUND 70Ω Automotive 4-Pin Ultra Mini-CSMD T/R; ESR (Equivalent Series Resistance): 70R; Case/Package: SMD/SMT; Component Kind: Standard; Frequency: 16MHz; Frequency Stability: 50ppm; Frequency Tolerance: 20ppm; Height: 0.031inch; Length: 0.126inch; Library Name: Altium Content Vault; Load Capacitance: 12pF; Max Operating Temperature: 125°C; Min Operating Temperature: -40°C; Mount: Surface Mount; Packaging: Tape and Reel; Ratings: AEC-Q200; RoHS Compliant: Yes; Width: 0.098inch
Y2 = CMP-27762-000095-1: Crystal 16MHz ±20ppm 18pF SMD-2 6mm x 3.5mm; ESR (Equivalent Series Resistance): 50R; Frequency Stability: 30ppm; Case/Package: CSMD; Component Kind: Standard; Depth: 3.5mm; Frequency: 16MHz; Frequency Tolerance: 20ppm; Height: 0.055inch; Lead Free: Lead Free; Length: 6mm; Library Name: Altium Content Vault; Load Capacitance: 18pF; Max Operating Temperature: 85°C; Min Operating Temperature: -40°C; Mount: Surface Mount; Number of Pins: 2; Operating Frequency: 16 MHz; Package Quantity: 1000; Packaging: Tape and Reel; Radiation Hardening: No; Resistor Type: Ceramic; Series: ABM7; Termination: SMD/SMT; Tolerance: 20ppm; Width: 3.3mm
